# Altium schematic sheet Input_Connectors.SchDoc
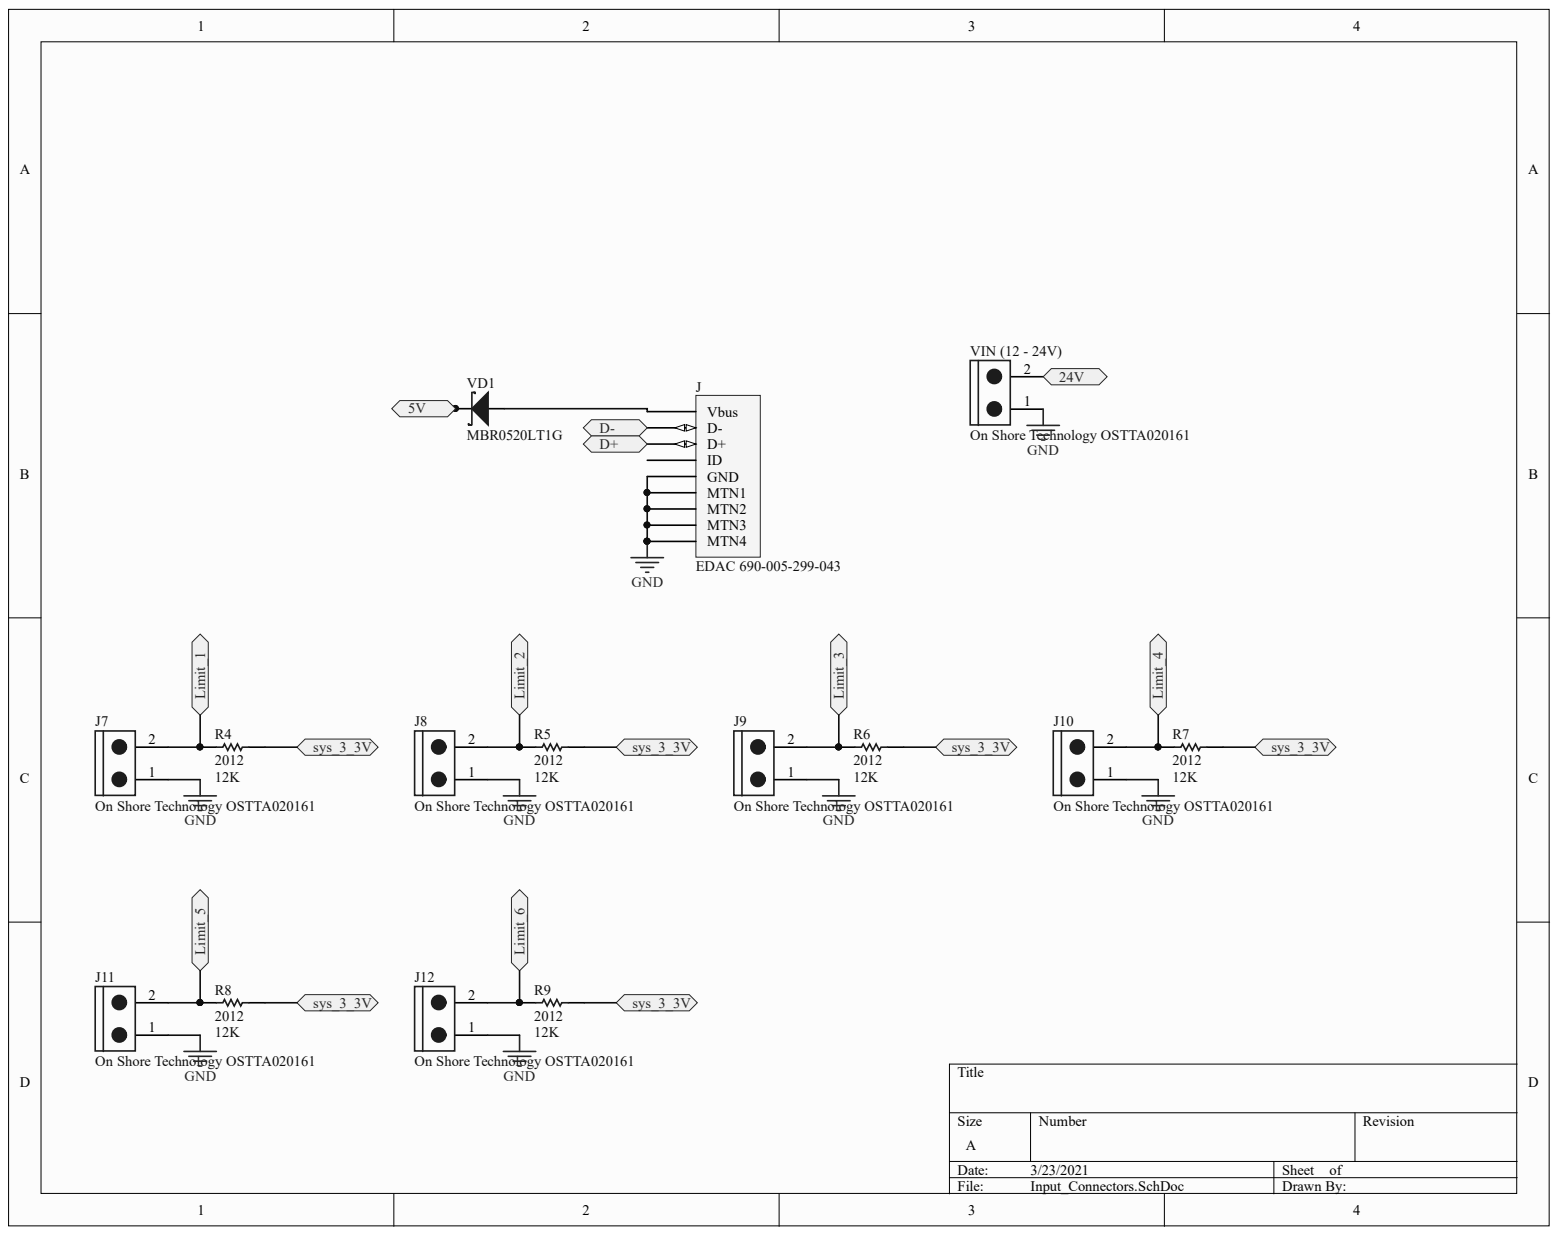
[diagram p0: Input_Connectors.SchDoc]

## Parts
J  EDAC 690-005-299-043  Manufacturer Part Number: 690-005-299-043  [PCB-0dqtvzwtjwndkeioqta0-1]
J7  On Shore Technology OSTTA020161  [PCBComponent_1 - duplicate]
J8  On Shore Technology OSTTA020161  [PCBComponent_1 - duplicate]
J9  On Shore Technology OSTTA020161  [PCBComponent_1 - duplicate]
J10  On Shore Technology OSTTA020161  [PCBComponent_1 - duplicate]
J11  On Shore Technology OSTTA020161  [PCBComponent_1 - duplicate]
J12  On Shore Technology OSTTA020161  [PCBComponent_1 - duplicate]
R4  Case/Package (SI): 2012  Resistance: 12K  [RESC2013X70X40ML20T20]  (hidden comment: Panasonic ERJ-6GEYJ822V)
R5  Case/Package (SI): 2012  Resistance: 12K  [RESC2013X70X40ML20T20]  (hidden comment: Panasonic ERJ-6GEYJ822V)
R6  Case/Package (SI): 2012  Resistance: 12K  [RESC2013X70X40ML20T20]  (hidden comment: Panasonic ERJ-6GEYJ822V)
R7  Case/Package (SI): 2012  Resistance: 12K  [RESC2013X70X40ML20T20]  (hidden comment: Panasonic ERJ-6GEYJ822V)
R8  Case/Package (SI): 2012  Resistance: 12K  [RESC2013X70X40ML20T20]  (hidden comment: Panasonic ERJ-6GEYJ822V)
R9  Case/Package (SI): 2012  Resistance: 12K  [RESC2013X70X40ML20T20]  (hidden comment: Panasonic ERJ-6GEYJ822V)
VD1  MBR0520LT1G  [SOD-123_B]
VIN_(12_-_24V)  On Shore Technology OSTTA020161  [PCBComponent_1 - duplicate]

## Ports
5V, 24V, D+, D-, LIMIT_1, LIMIT_2, LIMIT_3, LIMIT_4, LIMIT_5, LIMIT_6, SYS_3_3V

## Nets
GND: J.GND J.MTN1 J.MTN2 J.MTN3 J.MTN4 J7.1 J8.1 J9.1 J10.1 J11.1 J12.1 VIN_(12_-_24V).1
LIMIT_1: J7.2 R4.2 ; port LIMIT_1
LIMIT_2: J8.2 R5.2 ; port LIMIT_2
LIMIT_3: J9.2 R6.2 ; port LIMIT_3
LIMIT_4: J10.2 R7.2 ; port LIMIT_4
LIMIT_5: J11.2 R8.2 ; port LIMIT_5
LIMIT_6: J12.2 R9.2 ; port LIMIT_6
SYS_3_3V: R4.1 R5.1 R6.1 R7.1 R8.1 R9.1 ; port SYS_3_3V
5V: VD1.1 ; port 5V
24V: VIN_(12_-_24V).2 ; port 24V
D+: J.D+ ; port D+
D-: J.D- ; port D-
NetJ_Vbus: J.Vbus VD1.2

Not connected: J ID

## Library data of the parts
J = CMP-f10139da97e32ec6-8: Conn Mini Usb Rcpt Ra Type b Smd; Manufacturer: EDAC Inc.; Connector Type: USB - mini B; Gender: Receptacle; Mating Cycles: 1500; Component Kind: Standard; Library Name: CommunityVault; Mounting Type: Surface Mount, Right Angle; Octopart Url: https://octopart.com/search?q=690-005-299-043&currency=USD&specs=0
J7-J12 VIN_(12_-_24V) = CMP-2d54e45ab247f293-4: Connector Terminal Blocks 2 Position 5mm Solder Straight Thru-Hole 16A; Pin Pitch: 5.00 mm; Component Kind: Standard; Flammability Rating: UL 94 V-0; Housing Color: Blue; Housing Material: Nylon; Library Name: CommunityVault; Manufacturer Part Number: OSTTA020161; Number of Rows: 1; Octopart URL: http://octopart.com/ostta020161-on+shore+technology-309877; Size-Height: 12.6 mm
R4-R9 = CMP-3687578-2: Thick Film Resistors - SMD 0805 8.2Kohms 5% Tol; Lead-Free Status: Lead Free; Power Rating: 0.125 W; Voltage Rating (DC): 150.0 V; Case/Package: 0805; Component Kind: Standard; Library Name: CommunityVault; Lifecycle Status: Not Listed by Manufacturer; Manufacturer Part Number: ERJ-6GEYJ822V; Mounting Style: Surface Mount; OctopartID: 0556cf11906ada91; Octopart Url: https://octopart.com/erj-6geyj822v-panasonic-55423066; Packaging: Cut Tape (CT); Resistance Tolerance: ±5%; RoHS: Compliant; Size-Height: 0.0006 m; Size-Length: 0.002 m; Size-Width: 0.00125 m
VD1 = CMP-3786467-11: Rectifier, Schottky Power; Plastic Sod-123; 0.5 a @ +90c If; +125; 20v; 1000; Current Rating: 0.5 A; Forward Voltage: 0.385 V; Lead-Free Status: Lead Free; Polarity: Standard; Voltage Rating (DC): 20.0 V; Case/Package: SOD-123; Component Kind: Standard; Library Name: CommunityVault; Lifecycle Status: Active; Manufacturer Part Number: MBR0520LT1G; Mounting Style: Surface Mount; Number of Pins: 2; OctopartID: 81934899af805e54; Octopart Url: http://octopart.com/mbr0520lt1g-on+semiconductor-2933; REACH SVHC Compliance: No SVHC; RoHS: Compliant; Seller Landing  Url: https://octopart-clicks.com/click/track?country=US&ak=8157f166&sig=02b8313&sid=5489&ppid=2933&vpid=120602749&ct=offers
